# Altium schematic sheet 3-1-DI.SchDoc(3-1-DI)
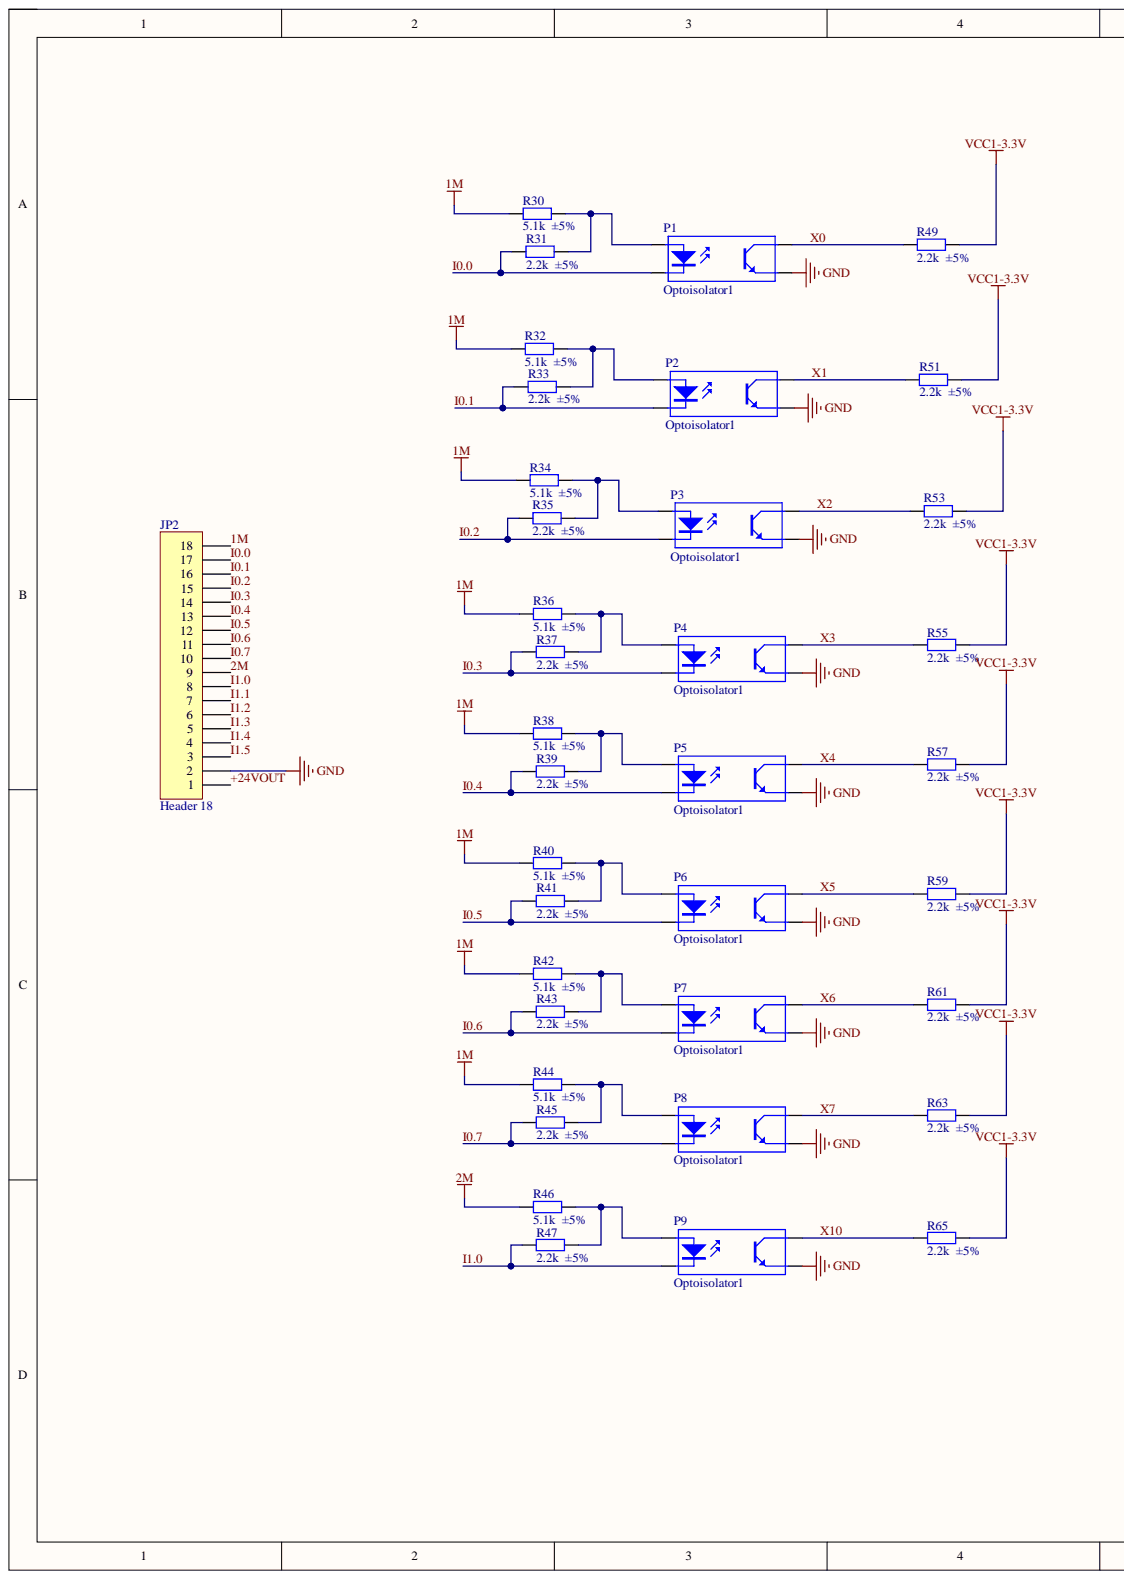
[diagram p0: 3-1-DI.SchDoc, left]
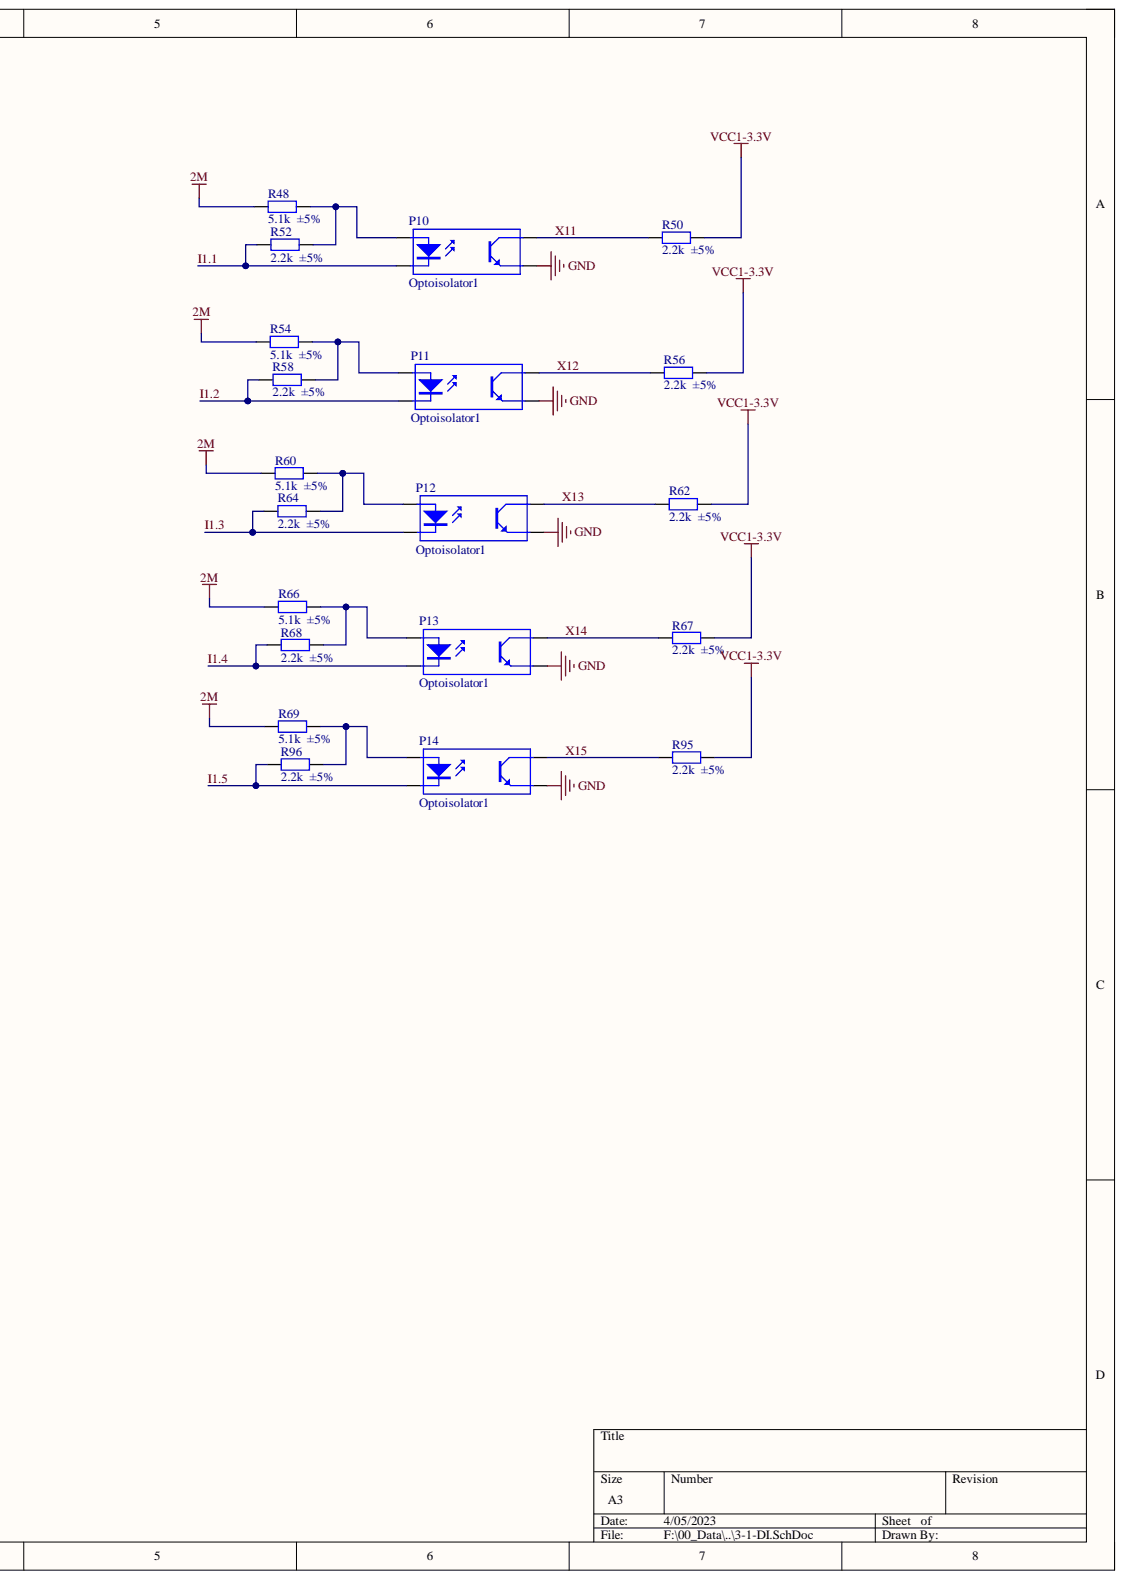
[diagram p1: 3-1-DI.SchDoc, right]

## Parts
JP2  Header 18  [HDR1X18]
P1  Optoisolator1  [DIP-4]
P2  Optoisolator1  [DIP-4]
P3  Optoisolator1  [DIP-4]
P4  Optoisolator1  [DIP-4]
P5  Optoisolator1  [DIP-4]
P6  Optoisolator1  [DIP-4]
P7  Optoisolator1  [DIP-4]
P8  Optoisolator1  [DIP-4]
P9  Optoisolator1  [DIP-4]
P10  Optoisolator1  [DIP-4]
P11  Optoisolator1  [DIP-4]
P12  Optoisolator1  [DIP-4]
P13  Optoisolator1  [DIP-4]
P14  Optoisolator1  [DIP-4]
R30  5.1k  ±5%  [0603_R]
R31  2.2k  ±5%  [0603_R]
R32  5.1k  ±5%  [0603_R]
R33  2.2k  ±5%  [0603_R]
R34  5.1k  ±5%  [0603_R]
R35  2.2k  ±5%  [0603_R]
R36  5.1k  ±5%  [0603_R]
R37  2.2k  ±5%  [0603_R]
R38  5.1k  ±5%  [0603_R]
R39  2.2k  ±5%  [0603_R]
R40  5.1k  ±5%  [0603_R]
R41  2.2k  ±5%  [0603_R]
R42  5.1k  ±5%  [0603_R]
R43  2.2k  ±5%  [0603_R]
R44  5.1k  ±5%  [0603_R]
R45  2.2k  ±5%  [0603_R]
R46  5.1k  ±5%  [0603_R]
R47  2.2k  ±5%  [0603_R]
R48  5.1k  ±5%  [0603_R]
R49  2.2k  ±5%  [0603_R]
R50  2.2k  ±5%  [0603_R]
R51  2.2k  ±5%  [0603_R]
R52  2.2k  ±5%  [0603_R]
R53  2.2k  ±5%  [0603_R]
R54  5.1k  ±5%  [0603_R]
R55  2.2k  ±5%  [0603_R]
R56  2.2k  ±5%  [0603_R]
R57  2.2k  ±5%  [0603_R]
R58  2.2k  ±5%  [0603_R]
R59  2.2k  ±5%  [0603_R]
R60  5.1k  ±5%  [0603_R]
R61  2.2k  ±5%  [0603_R]
R62  2.2k  ±5%  [0603_R]
R63  2.2k  ±5%  [0603_R]
R64  2.2k  ±5%  [0603_R]
R65  2.2k  ±5%  [0603_R]
R66  5.1k  ±5%  [0603_R]
R67  2.2k  ±5%  [0603_R]
R68  2.2k  ±5%  [0603_R]
R69  5.1k  ±5%  [0603_R]
R95  2.2k  ±5%  [0603_R]
R96  2.2k  ±5%  [0603_R]

## Nets
GND: JP2.2 P1.3 P2.3 P3.3 P4.3 P5.3 P6.3 P7.3 P8.3 P9.3 P10.3 P11.3 P12.3 P13.3 P14.3
VCC1-3.3V: R49.1 R50.1 R51.1 R53.1 R55.1 R56.1 R57.1 R59.1 R61.1 R62.1 R63.1 R65.1 R67.1 R95.1
1M: JP2.18 R30.2 R32.2 R34.2 R36.2 R38.2 R40.2 R42.2 R44.2
2M: JP2.9 R46.2 R48.2 R54.2 R60.2 R66.2 R69.2
+24VOUT: JP2.1
I0.0: JP2.17 P1.2 R31.1
I0.1: JP2.16 P2.2 R33.1
I0.2: JP2.15 P3.2 R35.1
I0.3: JP2.14 P4.2 R37.1
I0.4: JP2.13 P5.2 R39.1
I0.5: JP2.12 P6.2 R41.1
I0.6: JP2.11 P7.2 R43.1
I0.7: JP2.10 P8.2 R45.1
I1.0: JP2.8 P9.2 R47.1
I1.1: JP2.7 P10.2 R52.1
I1.2: JP2.6 P11.2 R58.1
I1.3: JP2.5 P12.2 R64.1
I1.4: JP2.4 P13.2 R68.1
I1.5: JP2.3 P14.2 R96.1
X0: P1.4 R49.2
X1: P2.4 R51.2
X2: P3.4 R53.2
X3: P4.4 R55.2
X4: P5.4 R57.2
X5: P6.4 R59.2
X6: P7.4 R61.2
X7: P8.4 R63.2
X10: P9.4 R65.2
X11: P10.4 R50.2
X12: P11.4 R56.2
X13: P12.4 R62.2
X14: P13.4 R67.2
X15: P14.4 R95.2
NetP1_1: P1.1 R30.1 R31.2
NetP2_1: P2.1 R32.1 R33.2
NetP3_1: P3.1 R34.1 R35.2
NetP4_1: P4.1 R36.1 R37.2
NetP5_1: P5.1 R38.1 R39.2
NetP6_1: P6.1 R40.1 R41.2
NetP7_1: P7.1 R42.1 R43.2
NetP8_1: P8.1 R44.1 R45.2
NetP9_1: P9.1 R46.1 R47.2
NetP10_1: P10.1 R48.1 R52.2
NetP11_1: P11.1 R54.1 R58.2
NetP12_1: P12.1 R60.1 R64.2
NetP13_1: P13.1 R66.1 R68.2
NetP14_1: P14.1 R69.1 R96.2

## Library data of the parts
JP2 = Header 18: Header, 18-Pin; Component Kind: Standard; LatestRevisionDate: 17-Jul-2002; LatestRevisionNote: Re-released for DXP Platform.; Library Name: Miscellaneous Connectors.IntLib; Signal Integrity: Connector; Publisher: Altium Limited
P1-P14 = Optoisolator1: 4-Pin Phototransistor Optocoupler; Component Kind: Standard; DatasheetVersion: Nov-2003; LatestRevisionDate: 04-Mar-2005; LatestRevisionNote: Sch pins 3 & 4 Swapped.; Library Name: Miscellaneous Devices.IntLib; PackageReference: DIP-4; Published: 24-Mar-1999; Publisher: Altium Limited
R30-R69 R95 R96 = Res_0603: 贴片电阻; Component Kind: Standard; ComponentLink1Description: 供应商链接; ComponentLink1URL: http://www.szlcsc.com/product/details_21903.html; Library Name: 02-Miscellaneous_Devices_ZSF_Library.IntLib; Package: 0603; Supplier: LC; SuppliersPartNumber: C21189
